# Altium schematic sheet Drone.SchDoc
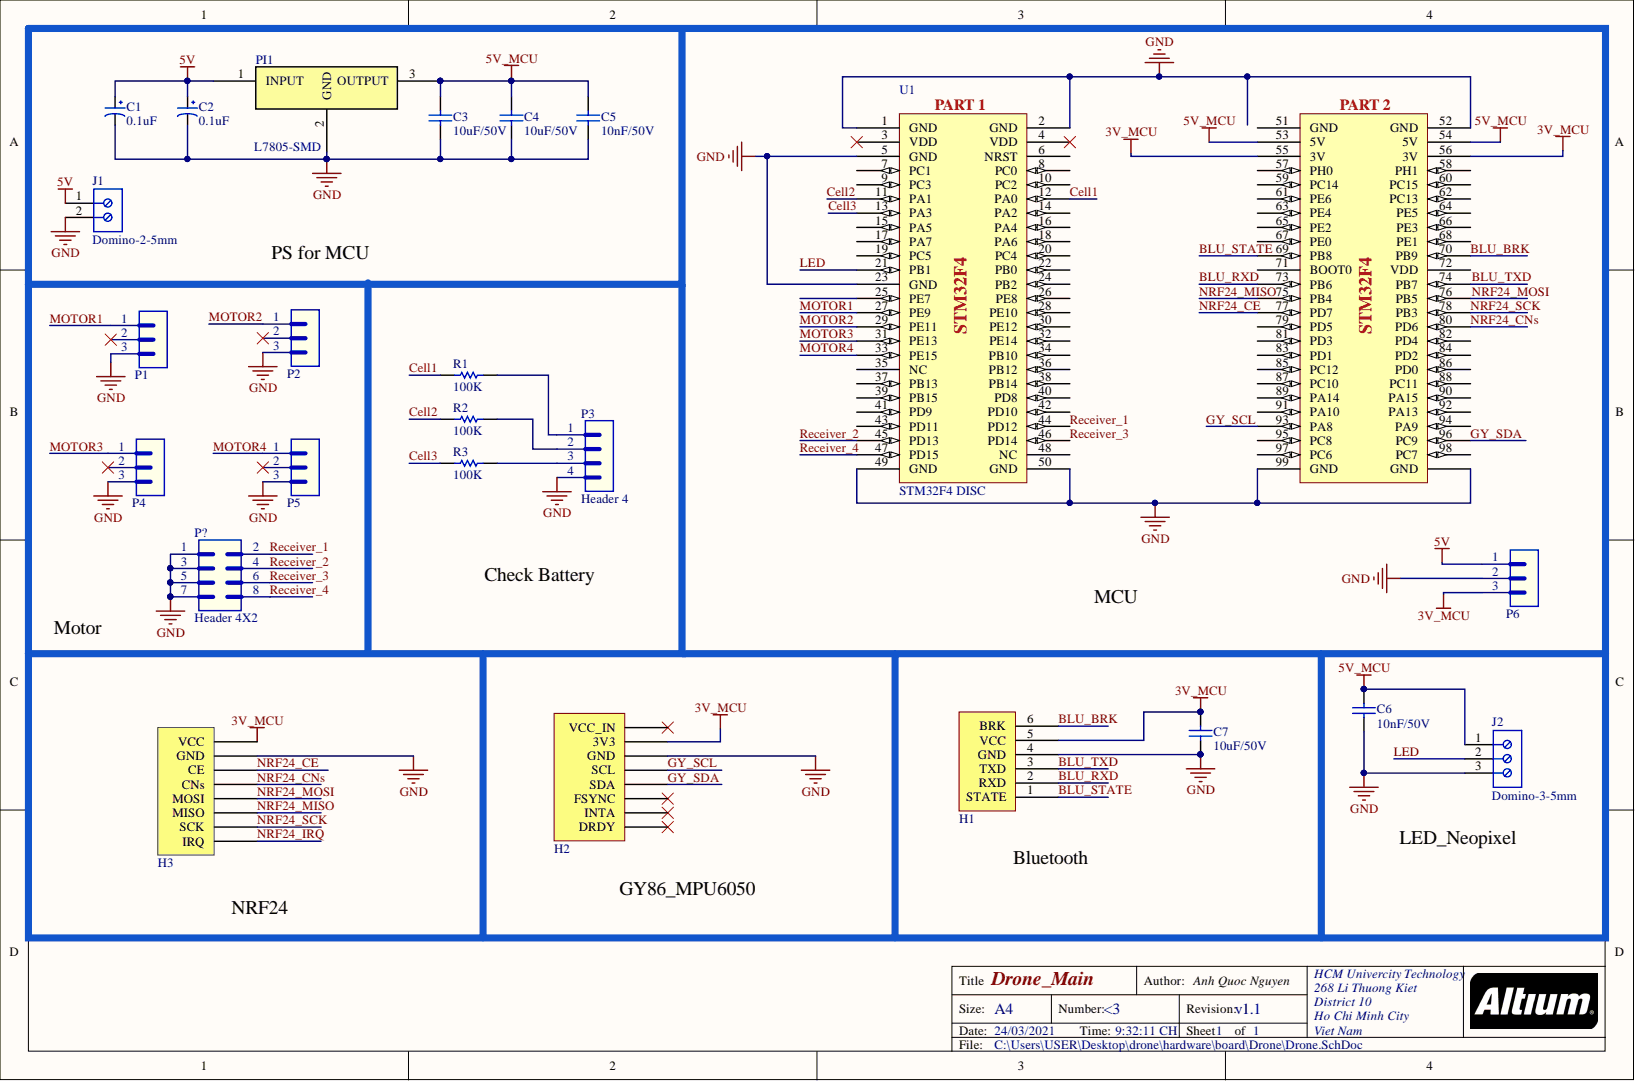
[diagram p0: Drone.SchDoc]

## Parts
C1  0.1uF  [tu hoa 5mm]
C2  0.1uF  [tu hoa 5mm]
C3  value 10uF/50V  [CAP-SM-0805]  (hidden comment: Cap-10uF-50V-0805)
C4  value 10uF/50V  [CAP-SM-0805]  (hidden comment: Cap-10uF-50V-0805)
C5  value 10nF/50V  [CAP-SM-0805]  (hidden comment: Cap-1nF-50V-0805)
C6  value 10nF/50V  [CAP-SM-0805]  (hidden comment: Cap-1nF-50V-0805)
C7  value 10uF/50V  [CAP-SM-0805]  (hidden comment: Cap-10uF-50V-0805)
H1  HC-05-06-TH  [HM-10S CC2541]  (value not drawn)
H2  MPU6050_Header  [XH B8B]  (value not drawn)
H3  NRF24  [XH B8B]
J1  Domino-2-5mm  [DOMINO-2-5MM]
J2  Domino-3-5mm  [DOMINO-3-5mm]
P1  Header 3  [JP3]  (value not drawn)
P2  Header 3  [JP3]  (value not drawn)
P3  Header 4  [JP4]
P4  Header 3  [JP3]  (value not drawn)
P5  Header 3  [JP3]  (value not drawn)
P6  Header 3  [JP3]  (value not drawn)
P?  Header 4X2  [JP4x2]
PI1  L7805-SMD  [D2PAK]
R1  value 100K  [RES-SM-0805]  (hidden comment: Res-100K-0805)
R2  value 100K  [RES-SM-0805]  (hidden comment: Res-100K-0805)
R3  value 100K  [RES-SM-0805]  (hidden comment: Res-100K-0805)
U1  STM32F4 DISC  [STM32F4 DISC]

## Nets
3V_MCU: C7.1 H1.5(VCC) H2.2(3V3) H3.1(VCC) P6.3 U1.55 U1.56(3V)
5V: C1.1 C2.1 J1.1 P6.1 PI1.1
5V_MCU: C3.1 C4.1 C5.2 C6.2 J2.1 PI1.3 U1.53 U1.54(5V)
GND: C1.2 C2.2 C3.2 C4.2 C5.1 C6.1 C7.2 H1.4(GND) H2.3(GND) H3.2(GND) J1.2 J2.3 P1.3 P2.3 P3.4 P4.3 P5.3 P6.2 P?.1 P?.3 P?.5 P?.7 PI1.2 U1.1(GND) U1.2 U1.5(GND) U1.23(GND) U1.49(GND) U1.50 U1.52(GND) U1.99 U1.GND
BLU_BRK: H1.6(BRK) U1.70(PB9)
BLU_RXD: H1.2(RXD) U1.73(BLU_RXD)
BLU_STATE: H1.1(STATE) U1.69
BLU_TXD: H1.3(TXD) U1.74(PB7)
Cell1: R1.1 U1.12(Cell1)
Cell2: R2.1 U1.11(PA1)
Cell3: R3.1 U1.13(PA3)
GY_SCL: H2.4(SCL) U1.93
GY_SDA: H2.5(SDA) U1.96(PC9)
LED: J2.2 U1.21(PB1)
MOTOR1: P1.1 U1.27(PE9)
MOTOR2: P2.1 U1.29(PE11)
MOTOR3: P4.1 U1.31(PE13)
MOTOR4: P5.1 U1.33(PE15)
NRF24_CE: H3.3(CE) U1.77
NRF24_CNs: H3.4(CNs) U1.80(PD6)
NRF24_IRQ: H3.8(IRQ)
NRF24_MISO: H3.6(MISO) U1.75
NRF24_MOSI: H3.5(MOSI) U1.76(PB5)
NRF24_SCK: H3.7(SCK) U1.78(PB3)
Receiver_1: P?.2 U1.44
Receiver_2: P?.4 U1.45(PD13)
Receiver_3: P?.6 U1.46(Receiver_3)
Receiver_4: P?.8 U1.47(PD15)
NetP3_1: P3.1 R1.2
NetP3_2: P3.2 R2.2
NetP3_3: P3.3 R3.2

Not connected: H2 1(VCC_IN) 6(FSYNC) 7(INTA) 8(DRDY); P1 2; P2 2; P4 2; P5 2; U1 3(VDD) 4 6 7(PC1) 8 9(PC3) 10 14 15(PA5) 16 17(PA7) 18 19(PC5) 20 22 24 25(PE7) 26 28 30 32 34 35(NC) 36 37(PB13) 38 39(PB15) 40 41(PD9) 42 43(PD11) 48 51(5V_MCU) 57 58(PH1) 59 60(PC15) 61 62(PC13) 63 64(PE5) 65 66(PE3) 67 68(PE1) 71 72(VDD) 79 81 82(PD4) 83 84(PD2) 85 86(PD0) 87 88(PC11) 89 90(PA15) 91 92(PA13) 94(PA9) 95 97 98(PC7)

## Library data of the parts
C1 C2 = Tu Hoa 0.1uF 50V 5x11: Cắm; Don_Vi: Con; Ma_Hang: MH006853; So_Chan: 2; Ten_Linh_Kien: Tụ Hóa 0.1uF 50V; Chung_Loai: Cắm; Component Kind: Standard; Library Name: THU VIEN SCH.SchLib
C3 C4 C7 = Cap-10uF-50V-0805: CAP CER 10UF 50V X5R 0805; Manufacturer: Yageo; Manufacturer Part Number: CC0805KKX5R9BB106; Component Kind: Standard; Library Name: Capacitor.SchLib; Local Supplier: thegioiic; Local Supplier Link: https://thegioiic.com/products/tu-10uf-50v-0805; Supplier 1: Digikey; Supplier 1 Link: https://www.digikey.com/product-detail/en/yageo/CC0805KKX5R9BB106/311-3499-2-ND/7164383; Supplier 1 Part Number: 311-3499-2-ND
C5 C6 = Cap-1nF-50V-0805: CAP CER 1000PF 100V X7R 0805; Manufacturer: Johanson Dielectrics Inc.; Manufacturer Part Number: 101X15W102MV4E; Component Kind: Standard; Library Name: Capacitor.SchLib; Local Supplier: thegioiic; Local Supplier Link: https://thegioiic.com/products/tu-1nf-50v-0805; Supplier 1: Digikey; Supplier 1 Link: https://www.digikey.com/product-detail/en/johanson-dielectrics-inc/101X15W102MV4E/709-1335-1-ND/2327235; Supplier 1 Part Number: 709-1335-1-ND
H1 = HM-10S CC2541: Component Kind: Standard; Local Supplier: HShop; Local Supplier Link: https://hshop.vn/products/mach-thu-phat-bluetooth-hc-06-do-ra-chon-slave; Local Supplier Part Number: HS000882; Library Name: Drone.SchLib
J1 = Domino-2-5mm: WR-TBL Serie 1347 Horizontal Cable Entry, Pressure Clamp, pitch 5mm, 2p; Part Number: 691134710002; Compliance: Lead free / RoHS; Modularity: Yes; PCB/Cable/Panel: PCB; Pin Number: 2; Rated Current [UL]: 16A; Working Voltage [UL]: 300VAC; Application: Pressure Clamp; Component Kind: Standard; ComponentLink1Description: Manufacturer; ComponentLink1URL: http://www.we-online.de; ComponentLink2Description: Datasheet; ComponentLink2URL: https://katalog.we-online.de/em/datasheet/691134710002.pdf; Library Name: Header-connector.SchLib; Mounting Technology: THT; Operating Temperature: -30 to +120degC; Packaging: Box; Pitch: 5mm; Published Date: October 2019; Type: Horizontal; Publisher: Wurth Elektronik
J2 = Domino-3-5mm: WR-TBL Serie 1347 Horizontal Cable Entry, Pressure Clamp, pitch 5mm, 3p; Part Number: 691134710003; Compliance: Lead free / RoHS; Modularity: Yes; PCB/Cable/Panel: PCB; Pin Number: 3; Rated Current [UL]: 16A; Working Voltage [UL]: 300VAC; Application: Pressure Clamp; Component Kind: Standard; ComponentLink1Description: Manufacturer; ComponentLink1URL: http://www.we-online.de; ComponentLink2Description: Datasheet; ComponentLink2URL: https://katalog.we-online.de/em/datasheet/691134710003.pdf; Library Name: Header-connector.SchLib; Mounting Technology: THT; Operating Temperature: -30 to +120degC; Packaging: Box; Pitch: 5mm; Published Date: October 2019; Type: Horizontal; Publisher: Wurth Elektronik
P1 P2 P4-P6 = Header 3: Header, 3-Pin; Component Kind: Standard; LatestRevisionDate: 17-Jul-2002; LatestRevisionNote: Re-released for DXP Platform.; Library Name: Header-connector.SchLib; Publisher: Altium Limited
P3 = Header 4: Header, 4-Pin; Component Kind: Standard; LatestRevisionDate: 17-Jul-2002; LatestRevisionNote: Re-released for DXP Platform.; Library Name: Header-connector.SchLib; Publisher: Altium Limited
P? = Header 4X2: Header, 4-Pin, Dual row; Component Kind: Standard; LatestRevisionDate: 17-Jul-2002; LatestRevisionNote: Re-released for DXP Platform.; Library Name: Header-connector.SchLib; Publisher: Altium Limited
PI1 = L7805-SMD: Manufacturer_Part_Number: L7805CD2T-TR; Built_By: EMA_UL_Team; Vendor: STMicroelectronics; Component Kind: Standard; RefDes: RefDes; Type: DEV; Copyright: Copyright (C) 2018 Accelerated Designs. All rights reserved; Library Name: balancing.SchLib
R1-R3 = Res-100K-0805: RES 0 OHM JUMPER 0805; Manufacturer: Vishay Dale; Manufacturer Part Number: WSL080500000ZEA9; Component Kind: Standard; Library Name: Resistor.SchLib; Local Supplier: thegioiic; Local Supplier Link: https://thegioiic.com/products/dien-tro-0-ohm-0805-5; Supplier 1: Digikey; Supplier 1 Link: https://www.digikey.com/product-detail/en/vishay-dale/WSL080500000ZEA9/541-3928-1-ND/7423223; Supplier 1 Part Number: 541-3928-1-ND
U1 = STM32F4 DISC: STM32F4 Discovery board. Chip on Board is Stm32f411VET6. Speed is 150MHz; Component Kind: Standard; Library Name: Drone.SchLib
H2 = MPU6050_Header: Component Kind: Standard; Library Name: Drone.SchLib
H3 = NRF24: Component Kind: Standard; Library Name: Drone.SchLib
